# Altium schematic sheet FPGAboard.SchDoc(FPGAboard)
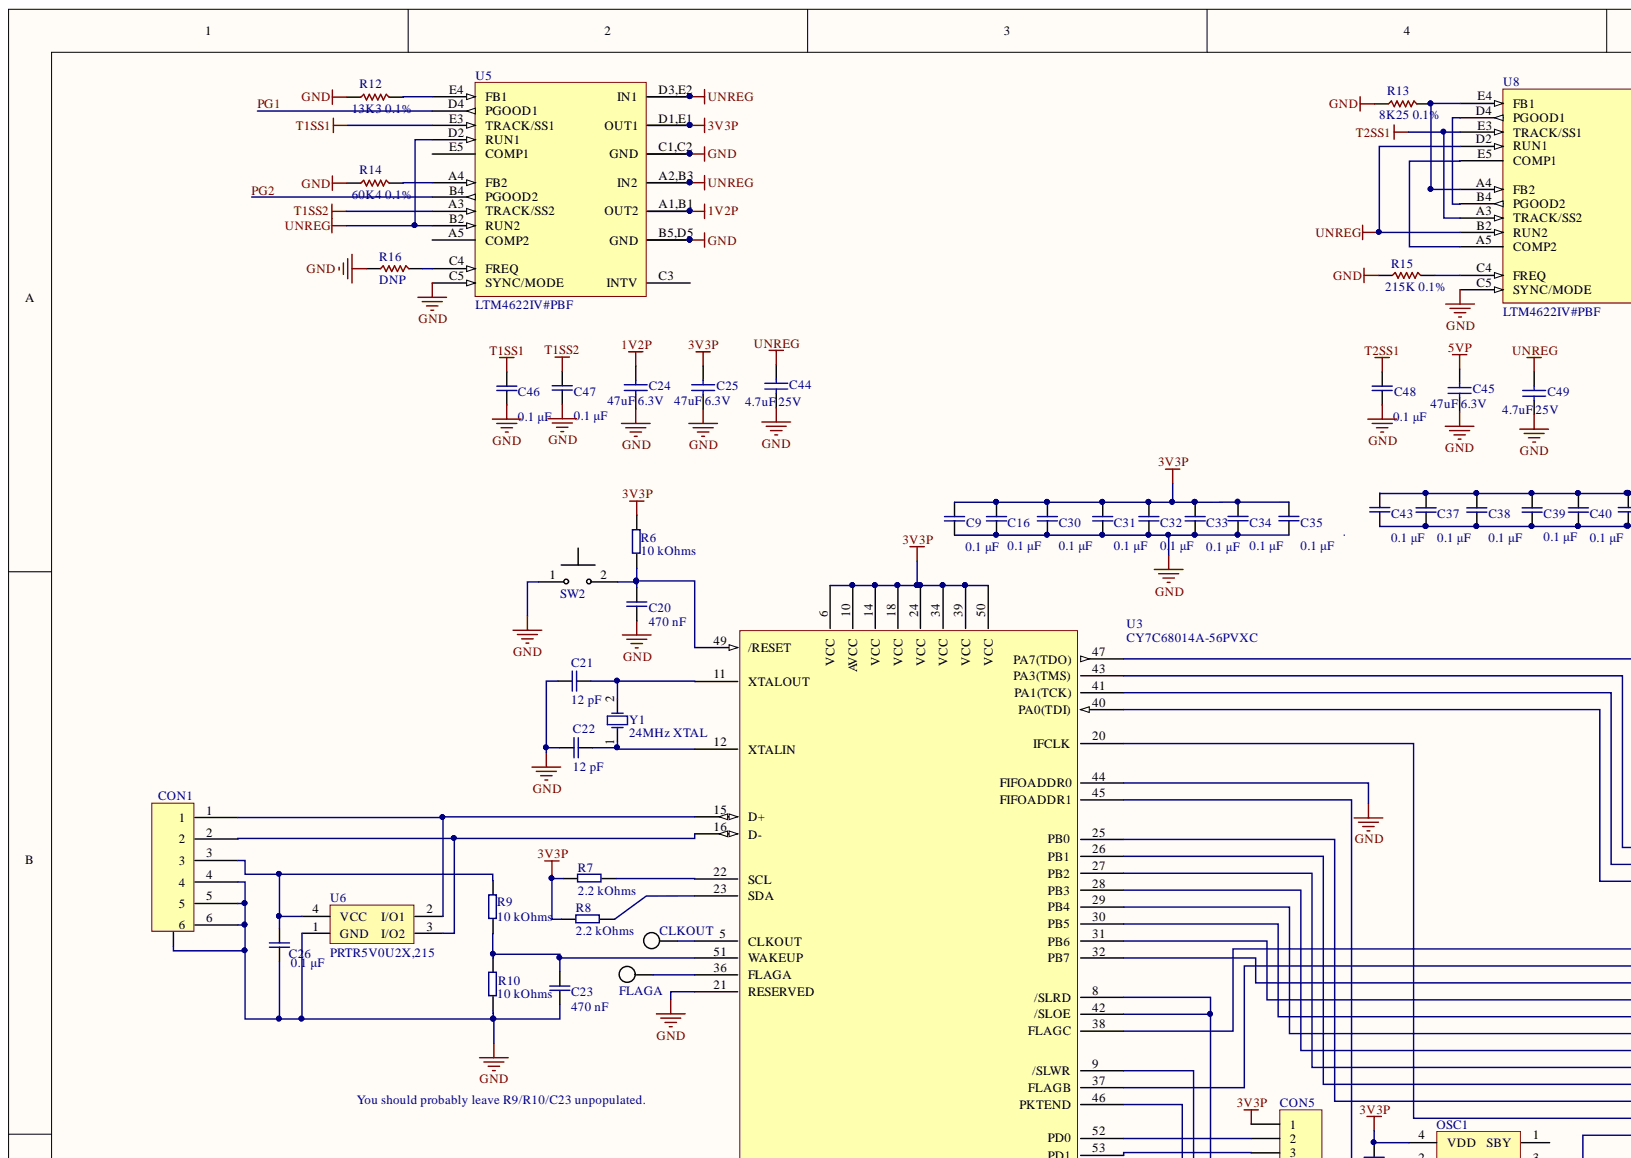
[diagram p0: FPGAboard.SchDoc, top left]
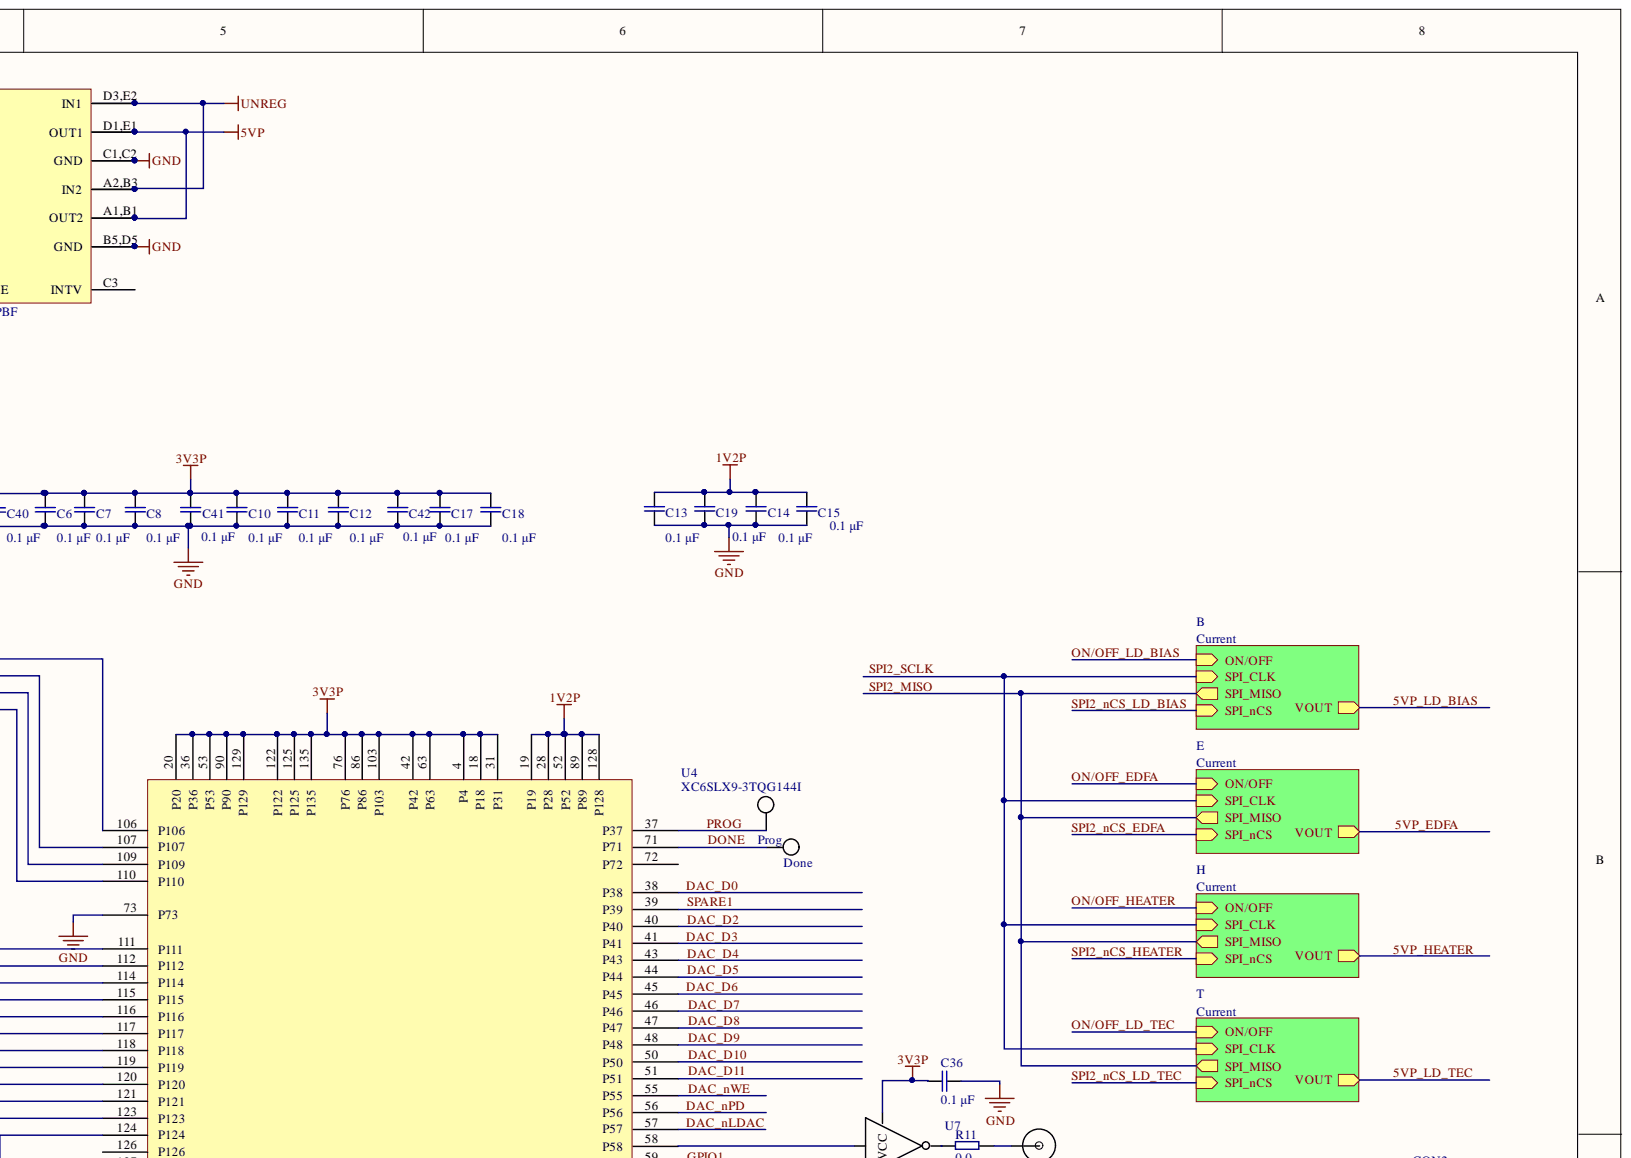
[diagram p1: FPGAboard.SchDoc, top right]
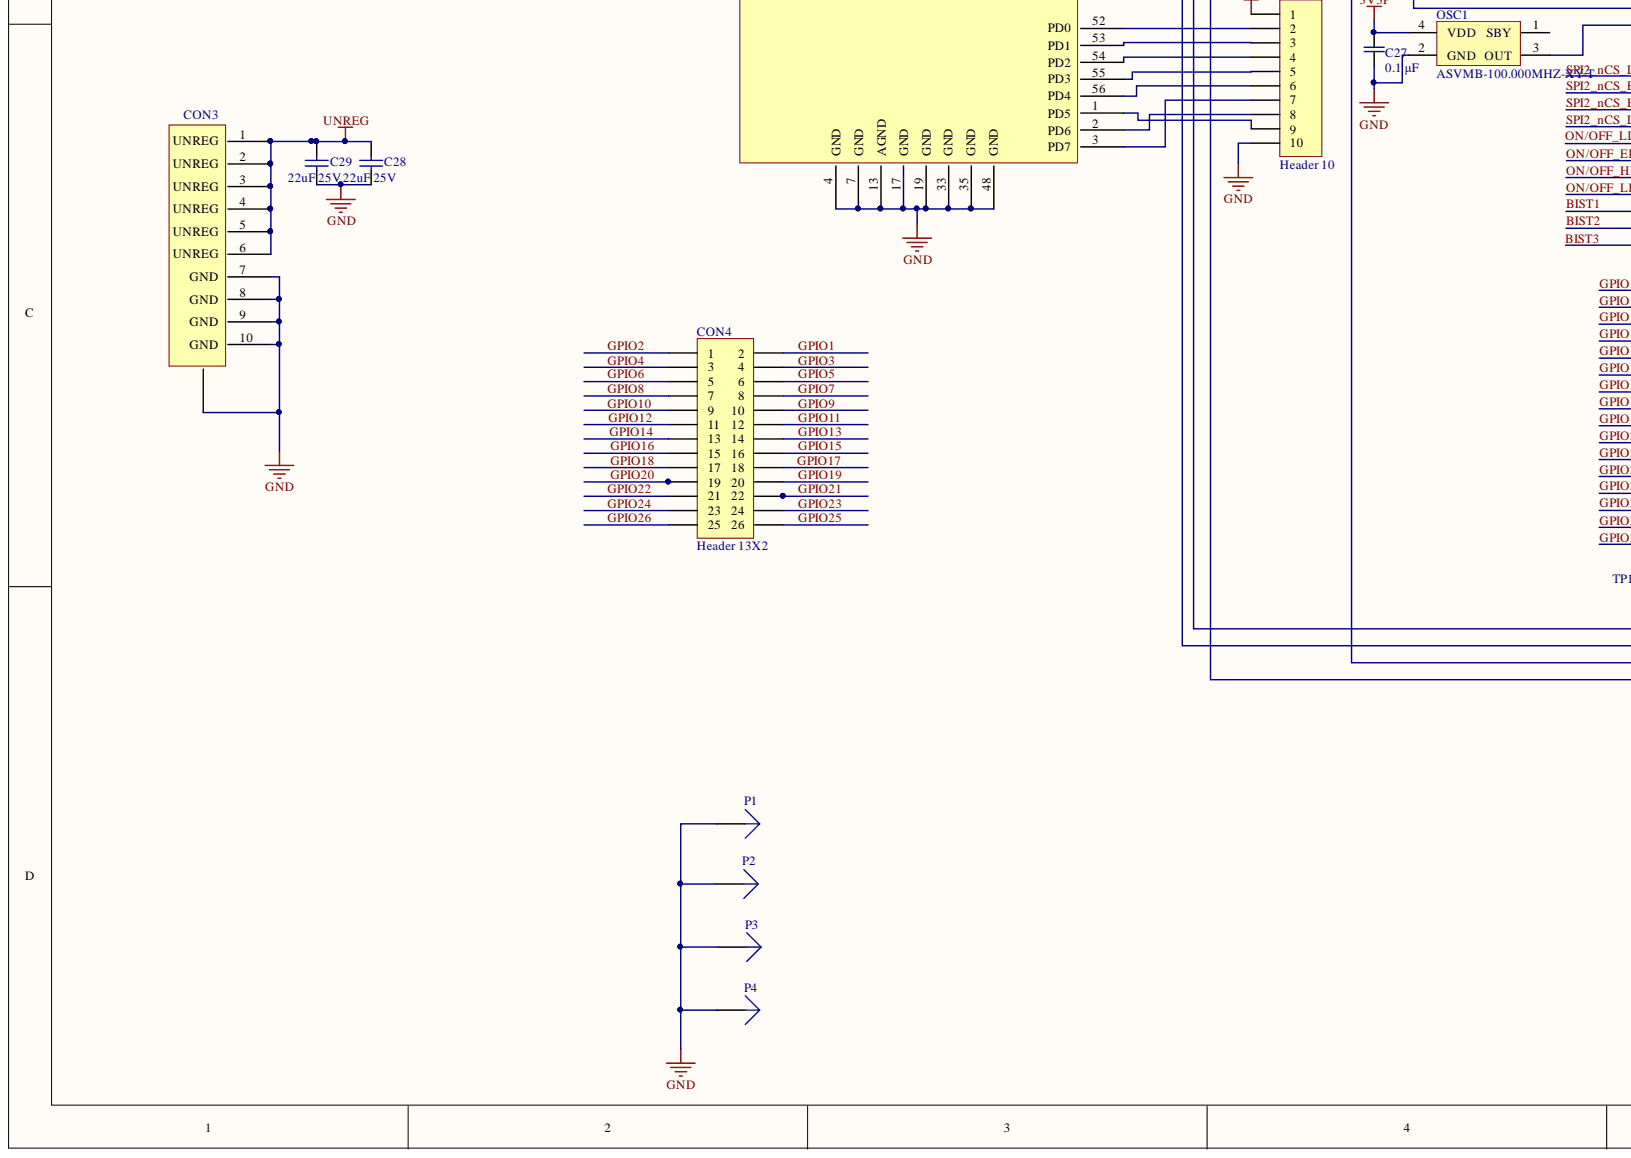
[diagram p2: FPGAboard.SchDoc, bottom left]
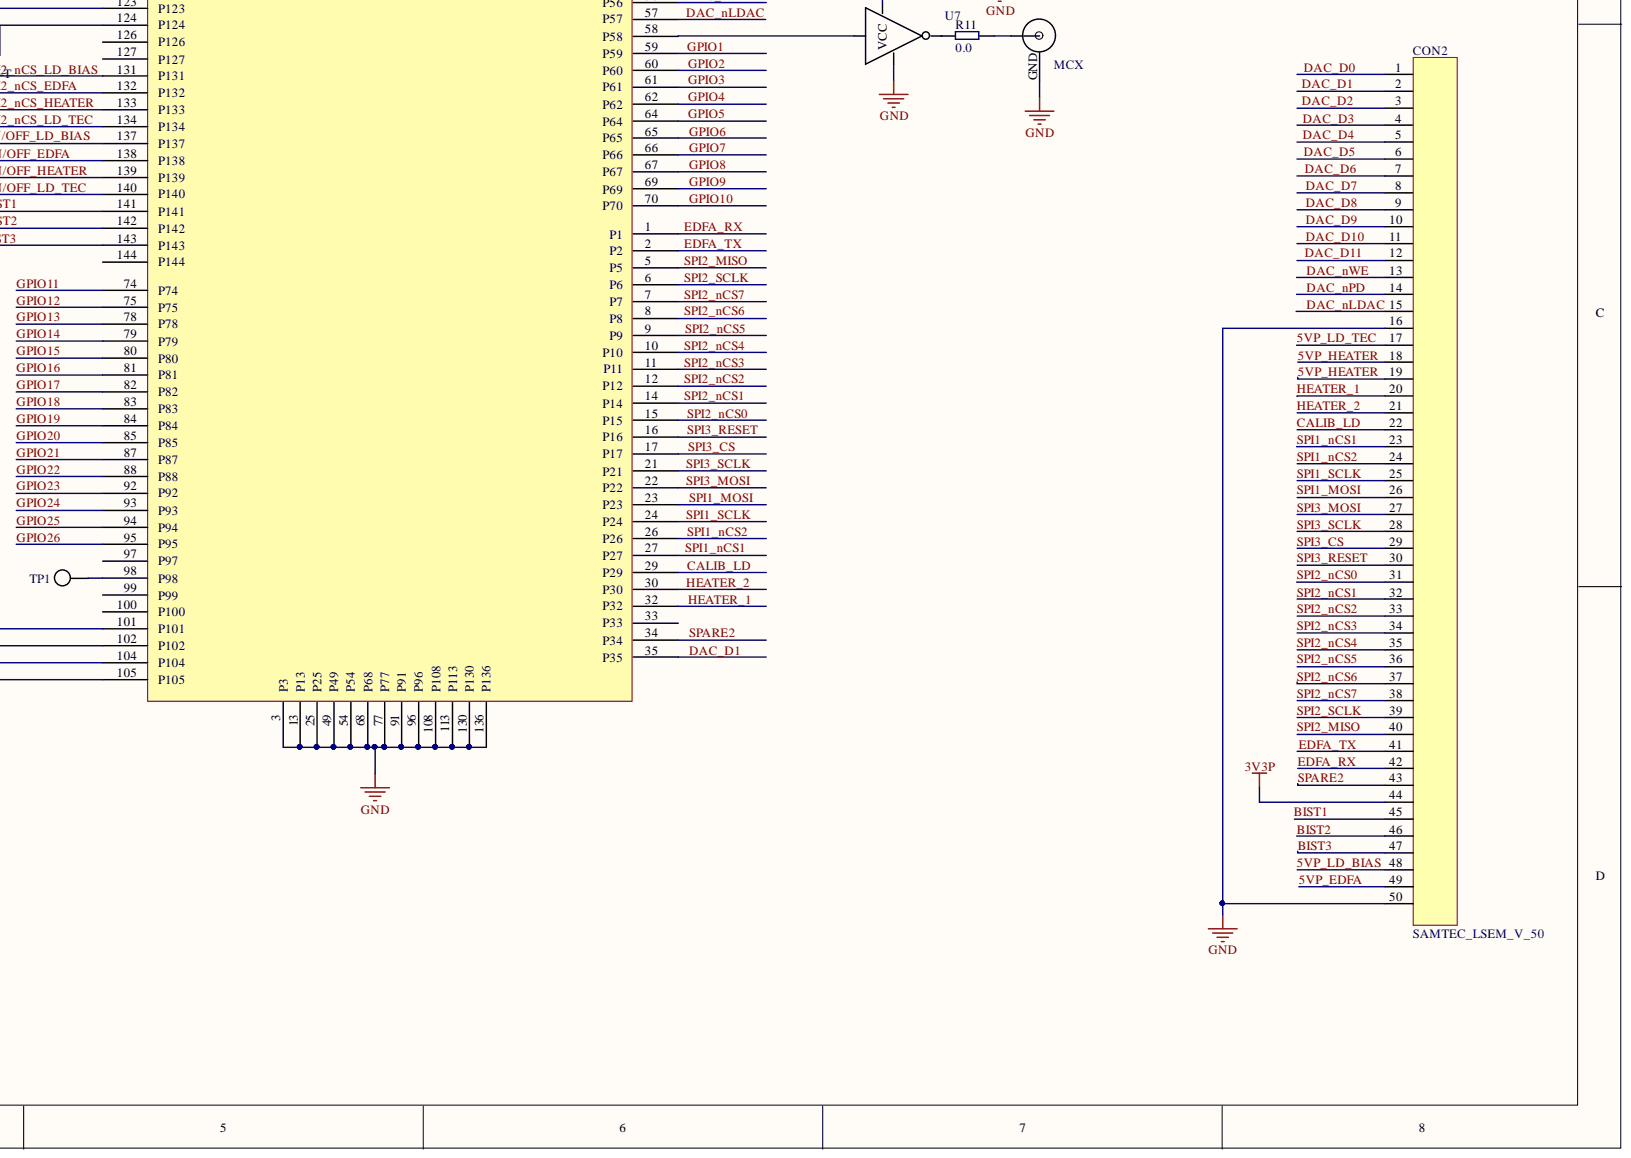
[diagram p3: FPGAboard.SchDoc, bottom right]

## Parts
C6  Capacitance: 0.1 μF  [0805_X7R_KEMET]  (hidden comment: C0805C104K5RACTU)
C7  Capacitance: 0.1 μF  [0805_X7R_KEMET]  (hidden comment: C0805C104K5RACTU)
C8  Capacitance: 0.1 μF  [0805_X7R_KEMET]  (hidden comment: C0805C104K5RACTU)
C9  C0805C104K5RACTU  [0805_X7R_KEMET]  (value not drawn)
C10  Capacitance: 0.1 μF  [0805_X7R_KEMET]  (hidden comment: C0805C104K5RACTU)
C11  Capacitance: 0.1 μF  [0805_X7R_KEMET]  (hidden comment: C0805C104K5RACTU)
C12  Capacitance: 0.1 μF  [0805_X7R_KEMET]  (hidden comment: C0805C104K5RACTU)
C13  Capacitance: 0.1 μF  [0805_X7R_KEMET]  (hidden comment: C0805C104K5RACTU)
C14  Capacitance: 0.1 μF  [0805_X7R_KEMET]  (hidden comment: C0805C104K5RACTU)
C15  Capacitance: 0.1 μF  [0805_X7R_KEMET]  (hidden comment: C0805C104K5RACTU)
C16  Capacitance: 0.1 μF  [0805_X7R_KEMET]  (hidden comment: C0805C104K5RACTU)
C17  Capacitance: 0.1 μF  [0805_X7R_KEMET]  (hidden comment: C0805C104K5RACTU)
C18  Capacitance: 0.1 μF  [0805_X7R_KEMET]  (hidden comment: C0805C104K5RACTU)
C19  Capacitance: 0.1 μF  [0805_X7R_KEMET]  (hidden comment: C0805C104K5RACTU)
C20  Capacitance - nF: 470 nF  [0805_X7R_KEMET]  (hidden comment: C0805C474K5RACTU)
C21  Capacitance: 12 pF  [0805_X7R_KEMET]  (hidden comment: C0805C120J5GACTU)
C22  Capacitance: 12 pF  [0805_X7R_KEMET]  (hidden comment: C0805C120J5GACTU)
C23  Capacitance - nF: 470 nF  [0805_X7R_KEMET]  (hidden comment: C0805C474K5RACTU)
C24  47uF 6.3V  [CAPC0805(2012)145_M]
C25  47uF 6.3V  [CAPC0805(2012)145_M]
C26  Capacitance: 0.1 μF  [0805_X7R_KEMET]  (hidden comment: C0805C104K5RACTU)
C27  Capacitance: 0.1 μF  [0805_X7R_KEMET]  (hidden comment: C0805C104K5RACTU)
C28  22uF 25V  value 22uF  [CAPC1210(3225)220_M]
C29  22uF 25V  value 22uF  [CAPC1210(3225)220_M]
C30  Capacitance: 0.1 μF  [0805_X7R_KEMET]  (hidden comment: C0805C104K5RACTU)
C31  Capacitance: 0.1 μF  [0805_X7R_KEMET]  (hidden comment: C0805C104K5RACTU)
C32  Capacitance: 0.1 μF  [0805_X7R_KEMET]  (hidden comment: C0805C104K5RACTU)
C33  Capacitance: 0.1 μF  [0805_X7R_KEMET]  (hidden comment: C0805C104K5RACTU)
C34  Capacitance: 0.1 μF  [0805_X7R_KEMET]  (hidden comment: C0805C104K5RACTU)
C35  Capacitance: 0.1 μF  [0805_X7R_KEMET]  (hidden comment: C0805C104K5RACTU)
C36  Capacitance: 0.1 μF  [0805_X7R_KEMET]  (hidden comment: C0805C104K5RACTU)
C37  Capacitance: 0.1 μF  [0805_X7R_KEMET]  (hidden comment: C0805C104K5RACTU)
C38  Capacitance: 0.1 μF  [0805_X7R_KEMET]  (hidden comment: C0805C104K5RACTU)
C39  Capacitance: 0.1 μF  [0805_X7R_KEMET]  (hidden comment: C0805C104K5RACTU)
C40  Capacitance: 0.1 μF  [0805_X7R_KEMET]  (hidden comment: C0805C104K5RACTU)
C41  Capacitance: 0.1 μF  [0805_X7R_KEMET]  (hidden comment: C0805C104K5RACTU)
C42  Capacitance: 0.1 μF  [0805_X7R_KEMET]  (hidden comment: C0805C104K5RACTU)
C43  Capacitance: 0.1 μF  [0805_X7R_KEMET]  (hidden comment: C0805C104K5RACTU)
C44  4.7uF 25V  [CAPC0603(1608)100_N]
C45  47uF 6.3V  [CAPC0805(2012)145_M]
C46  Capacitance: 0.1 μF  [0805_X7R_KEMET]  (hidden comment: C0805C104K5RACTU)
C47  Capacitance: 0.1 μF  [0805_X7R_KEMET]  (hidden comment: C0805C104K5RACTU)
C48  Capacitance: 0.1 μF  [0805_X7R_KEMET]  (hidden comment: C0805C104K5RACTU)
C49  4.7uF 25V  [CAPC0603(1608)100_N]
CLKOUT  TP  [TP]  (value not drawn)
CON1  T1M-06-F-SH-L-K  [T1M-06-F-SH-L-K]  (value not drawn)
CON2  SAMTEC_LSEM_V_50  [LSEM-150-XX.X-X-DV-A-X-XX-TR]
CON3  T1M-10-F-SH-L-K  [T1M-10-F-SH-L-K]  (value not drawn)
CON4  Header 13X2  [HDR2X13]
CON5  Header 10  [HDR1X10]
Done  TP  [TP]  (value not drawn)
FLAGA  TP  [TP]  (value not drawn)
MCX  0734151061  [MCX]  (value not drawn)
OSC1  ASVMB-100.000MHZ-XY-T  [ASVMB-100.000MHZ-XY-T]
P1  Plug  [PIN1]  (value not drawn)
P2  Plug  [PIN1]  (value not drawn)
P3  Plug  [PIN1]  (value not drawn)
P4  Plug  [PIN1]  (value not drawn)
Prog  TP  [TP]  (value not drawn)
R6  Resistance: 10 kOhms  [CRCW0805_VISHAY]  (hidden comment: CRCW080510K0FKEA_)
R7  Resistance: 2.2 kOhms  [CRCW0805_VISHAY]  (hidden comment: CRCW08052K20FKEA)
R8  Resistance: 2.2 kOhms  [CRCW0805_VISHAY]  (hidden comment: CRCW08052K20FKEA)
R9  Resistance: 10 kOhms  [CRCW0805_VISHAY]  (hidden comment: CRCW080510K0FKEA_)
R10  Resistance: 10 kOhms  [CRCW0805_VISHAY]  (hidden comment: CRCW080510K0FKEA_)
R11  Resistance (Ohms): 0.0  [CRCW0805_VISHAY]  (hidden comment: CRCW08050000Z0EA)
R12  13K3 0.1%  [0402]
R13  8K25 0.1%  [0402]
R14  60K4 0.1%  [0402]
R15  215K 0.1%  [0603]
R16  DNP  [0402]
SW2  PTS645SM43SMTR92 LFS  [PTS645SM43SMTR92 LFS]  (value not drawn)
TP1  TP  [TP]
U3  CY7C68014A-56PVXC  [SSOP-56]
U4  XC6SLX9-3TQG144I  [TQG144]
U5  LTM4622IV#PBF  [LGA 25]
U6  PRTR5V0U2X,215  [SOT143B]
U7  SN74LVC1G04QDBVRQ1  [SN74LVC1G04-Q1]  (value not drawn)
U8  LTM4622IV#PBF  [LGA 25]
Y1  24MHz XTAL  Frequency: 24MHz  [HC-49S SMD 9C Series]

## Nets
1V2P: C13.1 C14.1 C15.1 C19.1 C24.2 U4.19(P19) U4.28(P28) U4.52(P52) U4.89(P89) U4.128(P128) U5.A1,B1(OUT2) U5.B1
3V3P: C6.1 C7.1 C8.1 C9.1 C10.1 C11.1 C12.1 C16.1 C17.1 C18.1 C25.2 C27.1 C30.1 C31.1 C32.1 C33.1 C34.1 C35.1 C36.1 C37.1 C38.1 C39.1 C40.1 C41.1 C42.1 C43.1 CON2.44 CON5.1 OSC1.4(VDD) R6.1 R7.1 R8.1 U3.6(VCC) U3.10(AVCC) U3.14(VCC) U3.18(VCC) U3.24(VCC) U3.34(VCC) U3.39(VCC) U3.50(VCC) U4.4(P4) U4.18(P18) U4.20(P20) U4.31(P31) U4.36(P36) U4.42(P42) U4.53(P53) U4.63(P63) U4.76(P76) U4.86(P86) U4.90(P90) U4.103(P103) U4.122(P122) U4.125(P125) U4.129(P129) U4.135(P135) U5.D1,E1(OUT1) U5.E1 U7.5(VCC)
5VP: C45.2 U8.A1,B1(OUT2) U8.B1 U8.D1,E1(OUT1) U8.E1
GND: C6.2 C7.2 C8.2 C9.2 C10.2 C11.2 C12.2 C13.2 C14.2 C15.2 C16.2 C17.2 C18.2 C19.2 C20.2 C21.1 C22.1 C23.2 C24.1 C25.1 C26.2 C27.2 C28.1 C29.1 C30.2 C31.2 C32.2 C33.2 C34.2 C35.2 C36.2 C37.2 C38.2 C39.2 C40.2 C41.2 C42.2 C43.2 C44.1 C45.1 C46.2 C47.2 C48.2 C49.1 CON1.4 CON1.5 CON1.6 CON1.GND CON2.16 CON2.50 CON3.7(GND) CON3.8(GND) CON3.9(GND) CON3.10(GND) CON3.GND CON5.10 MCX.GND OSC1.2(GND) P1.1 P2.1 P3.1 P4.1 R10.2 R12.2 R13.2 R14.2 R15.2 R16.2 SW2.1 U3.4(GND) U3.7(GND) U3.13(AGND) U3.17(GND) U3.19(GND) U3.21(RESERVED) U3.33(GND) U3.35(GND) U3.44(FIFOADDR0) U3.48(GND) U4.3(P3) U4.13(P13) U4.25(P25) U4.49(P49) U4.54(P54) U4.68(P68) U4.73(P73) U4.77(P77) U4.91(P91) U4.96(P96) U4.108(P108) U4.113(P113) U4.130(P130) U4.136(P136) U5.B5,D5(GND) U5.C1,C2(GND) U5.C2 U5.C5(SYNC/MODE) U5.D5 U6.1(GND) U7.3 U8.B5,D5(GND) U8.C1,C2(GND) U8.C2 U8.C5(SYNC/MODE) U8.D5
T1SS1: C46.1 U5.E3(TRACK/SS1)
T1SS2: C47.1 U5.A3(TRACK/SS2)
T2SS1: C48.1 U8.A3(TRACK/SS2) U8.E3(TRACK/SS1)
UNREG: C28.2 C29.2 C44.2 C49.2 CON3.1(UNREG) CON3.2(UNREG) CON3.3(UNREG) CON3.4(UNREG) CON3.5(UNREG) CON3.6(UNREG) U5.A2,B3(IN2) U5.B2(RUN2) U5.B3 U5.D2(RUN1) U5.D3,E2(IN1) U5.E2 U8.A2,B3(IN2) U8.B2(RUN2) U8.B3 U8.D2(RUN1) U8.D3,E2(IN1) U8.E2
5VP_EDFA: CON2.49
5VP_HEATER: CON2.18 CON2.19
5VP_LD_BIAS: CON2.48
5VP_LD_TEC: CON2.17
BIST1: CON2.45 U4.141(P141)
BIST2: CON2.46 U4.142(P142)
BIST3: CON2.47 U4.143(P143)
CALIB_LD: CON2.22 U4.29(P29)
DAC_D0: CON2.1 U4.38(P38)
DAC_D1: CON2.2 U4.35(P35)
DAC_D2: CON2.3 U4.40(P40)
DAC_D3: CON2.4 U4.41(P41)
DAC_D4: CON2.5 U4.43(P43)
DAC_D5: CON2.6 U4.44(P44)
DAC_D6: CON2.7 U4.45(P45)
DAC_D7: CON2.8 U4.46(P46)
DAC_D8: CON2.9 U4.47(P47)
DAC_D9: CON2.10 U4.48(P48)
DAC_D10: CON2.11 U4.50(P50)
DAC_D11: CON2.12 U4.51(P51)
DAC_nLDAC: CON2.15 U4.57(P57)
DAC_nPD: CON2.14 U4.56(P56)
DAC_nWE: CON2.13 U4.55(P55)
DONE: Done.1 U4.71(P71)
EDFA_RX: CON2.42 U4.1(P1)
EDFA_TX: CON2.41 U4.2(P2)
GPIO1: CON4.2 U4.59(P59)
GPIO2: CON4.1 U4.60(P60)
GPIO3: CON4.4 U4.61(P61)
GPIO4: CON4.3 U4.62(P62)
GPIO5: CON4.6 U4.64(P64)
GPIO6: CON4.5 U4.65(P65)
GPIO7: CON4.8 U4.66(P66)
GPIO8: CON4.7 U4.67(P67)
GPIO9: CON4.10 U4.69(P69)
GPIO10: CON4.9 U4.70(P70)
GPIO11: CON4.12 U4.74(P74)
GPIO12: CON4.11 U4.75(P75)
GPIO13: CON4.14 U4.78(P78)
GPIO14: CON4.13 U4.79(P79)
GPIO15: CON4.16 U4.80(P80)
GPIO16: CON4.15 U4.81(P81)
GPIO17: CON4.18 U4.82(P82)
GPIO18: CON4.17 U4.83(P83)
GPIO19: CON4.20 U4.84(P84)
GPIO20: CON4.19 U4.85(P85)
GPIO21: CON4.22 U4.87(P87)
GPIO22: CON4.21 U4.88(P88)
GPIO23: CON4.24 U4.92(P92)
GPIO24: CON4.23 U4.93(P93)
GPIO25: CON4.26 U4.94(P94)
GPIO26: CON4.25 U4.95(P95)
HEATER_1: CON2.20 U4.32(P32)
HEATER_2: CON2.21 U4.30(P30)
ON/OFF_EDFA: U4.138(P138)
ON/OFF_HEATER: U4.139(P139)
ON/OFF_LD_BIAS: U4.137(P137)
ON/OFF_LD_TEC: U4.140(P140)
PG1: U5.D4(PGOOD1)
PG2: U5.B4(PGOOD2)
PROG: Prog.1 U4.37(P37)
SPARE1: U4.39(P39)
SPARE2: CON2.43 U4.34(P34)
SPI1_MOSI: CON2.26 U4.23(P23)
SPI1_nCS1: CON2.23 U4.27(P27)
SPI1_nCS2: CON2.24 U4.26(P26)
SPI1_SCLK: CON2.25 U4.24(P24)
SPI2_MISO: CON2.40 U4.5(P5)
SPI2_nCS0: CON2.31 U4.15(P15)
SPI2_nCS1: CON2.32 U4.14(P14)
SPI2_nCS2: CON2.33 U4.12(P12)
SPI2_nCS3: CON2.34 U4.11(P11)
SPI2_nCS4: CON2.35 U4.10(P10)
SPI2_nCS5: CON2.36 U4.9(P9)
SPI2_nCS6: CON2.37 U4.8(P8)
SPI2_nCS7: CON2.38 U4.7(P7)
SPI2_nCS_EDFA: U4.132(P132)
SPI2_nCS_HEATER: U4.133(P133)
SPI2_nCS_LD_BIAS: U4.131(P131)
SPI2_nCS_LD_TEC: U4.134(P134)
SPI2_SCLK: CON2.39 U4.6(P6)
SPI3_CS: CON2.29 U4.17(P17)
SPI3_MOSI: CON2.27 U4.22(P22)
SPI3_RESET: CON2.30 U4.16(P16)
SPI3_SCLK: CON2.28 U4.21(P21)
NetC20_1: C20.1 R6.2 SW2.2 U3.49(/RESET)
NetC21_2: C21.2 U3.11(XTALOUT) Y1.2
NetC22_2: C22.2 U3.12(XTALIN) Y1.1
NetC23_1: C23.1 R9.2 R10.1 U3.51(WAKEUP)
NetC26_1: C26.1 CON1.3 R9.1 U6.4(VCC)
NetCLKOUT_1: CLKOUT.1 U3.5(CLKOUT)
NetCON1_1: CON1.1 U3.15(D+) U6.2(I/O1)
NetCON1_2: CON1.2 U3.16(D-) U6.3(I/O2)
NetCON5_2: CON5.2 U3.52(PD0)
NetCON5_3: CON5.3 U3.53(PD1)
NetCON5_4: CON5.4 U3.54(PD2)
NetCON5_5: CON5.5 U3.55(PD3)
NetCON5_6: CON5.6 U3.56(PD4)
NetCON5_7: CON5.7 U3.3(PD7)
NetCON5_8: CON5.8 U3.2(PD6)
NetCON5_9: CON5.9 U3.1(PD5)
NetFLAGA_1: FLAGA.1 U3.36(FLAGA)
NetMCX_IN: MCX.IN R11.2
NetOSC1_1: OSC1.1(SBY)
NetOSC1_3: OSC1.3(OUT) U4.124(P124)
NetR7_2: R7.2 U3.22(SCL)
NetR8_2: R8.2 U3.23(SDA)
NetR11_1: R11.1 U7.4
NetR12_1: R12.1 U5.E4(FB1)
NetR13_1: R13.1 U8.A4(FB2) U8.E4(FB1)
NetR14_1: R14.1 U5.A4(FB2)
NetR15_1: R15.1 U8.C4(FREQ)
NetR16_1: R16.1 U5.C4(FREQ)
NetTP1_1: TP1.1 U4.98(P98)
NetU3_8: U3.8(/SLRD) U3.42(/SLOE) U4.105(P105)
NetU3_9: U3.9(/SLWR) U4.101(P101)
NetU3_20: U3.20(IFCLK) U4.123(P123)
NetU3_25: U3.25(PB0) U4.121(P121)
NetU3_26: U3.26(PB1) U4.120(P120)
NetU3_27: U3.27(PB2) U4.119(P119)
NetU3_28: U3.28(PB3) U4.118(P118)
NetU3_29: U3.29(PB4) U4.117(P117)
NetU3_30: U3.30(PB5) U4.116(P116)
NetU3_31: U3.31(PB6) U4.115(P115)
NetU3_32: U3.32(PB7) U4.114(P114)
NetU3_37: U3.37(FLAGB) U4.112(P112)
NetU3_38: U3.38(FLAGC) U4.111(P111)
NetU3_40: U3.40(PA0(TDI)) U4.110(P110)
NetU3_41: U3.41(PA1(TCK)) U4.109(P109)
NetU3_43: U3.43(PA3(TMS)) U4.107(P107)
NetU3_45: U3.45(FIFOADDR1) U4.104(P104)
NetU3_46: U3.46(PKTEND) U4.102(P102)
NetU3_47: U3.47(PA7(TDO)) U4.106(P106)
NetU4_33: U4.33(P33)
NetU4_58: U4.58(P58) U7.2
NetU4_72: U4.72(P72)
NetU4_97: U4.97(P97)
NetU4_99: U4.99(P99)
NetU4_100: U4.100(P100)
NetU4_126: U4.126(P126)
NetU4_127: U4.127(P127)
NetU4_144: U4.144(P144)
NetU5_A5: U5.A5(COMP2)
NetU5_C3: U5.C3(INTV)
NetU5_E5: U5.E5(COMP1)
NetU8_A5: U8.A5(COMP2) U8.E5(COMP1)
NetU8_B4: U8.B4(PGOOD2) U8.D4(PGOOD1)
NetU8_C3: U8.C3(INTV)
N00115:
